# Altium schematic sheet Sheet1.SchDoc
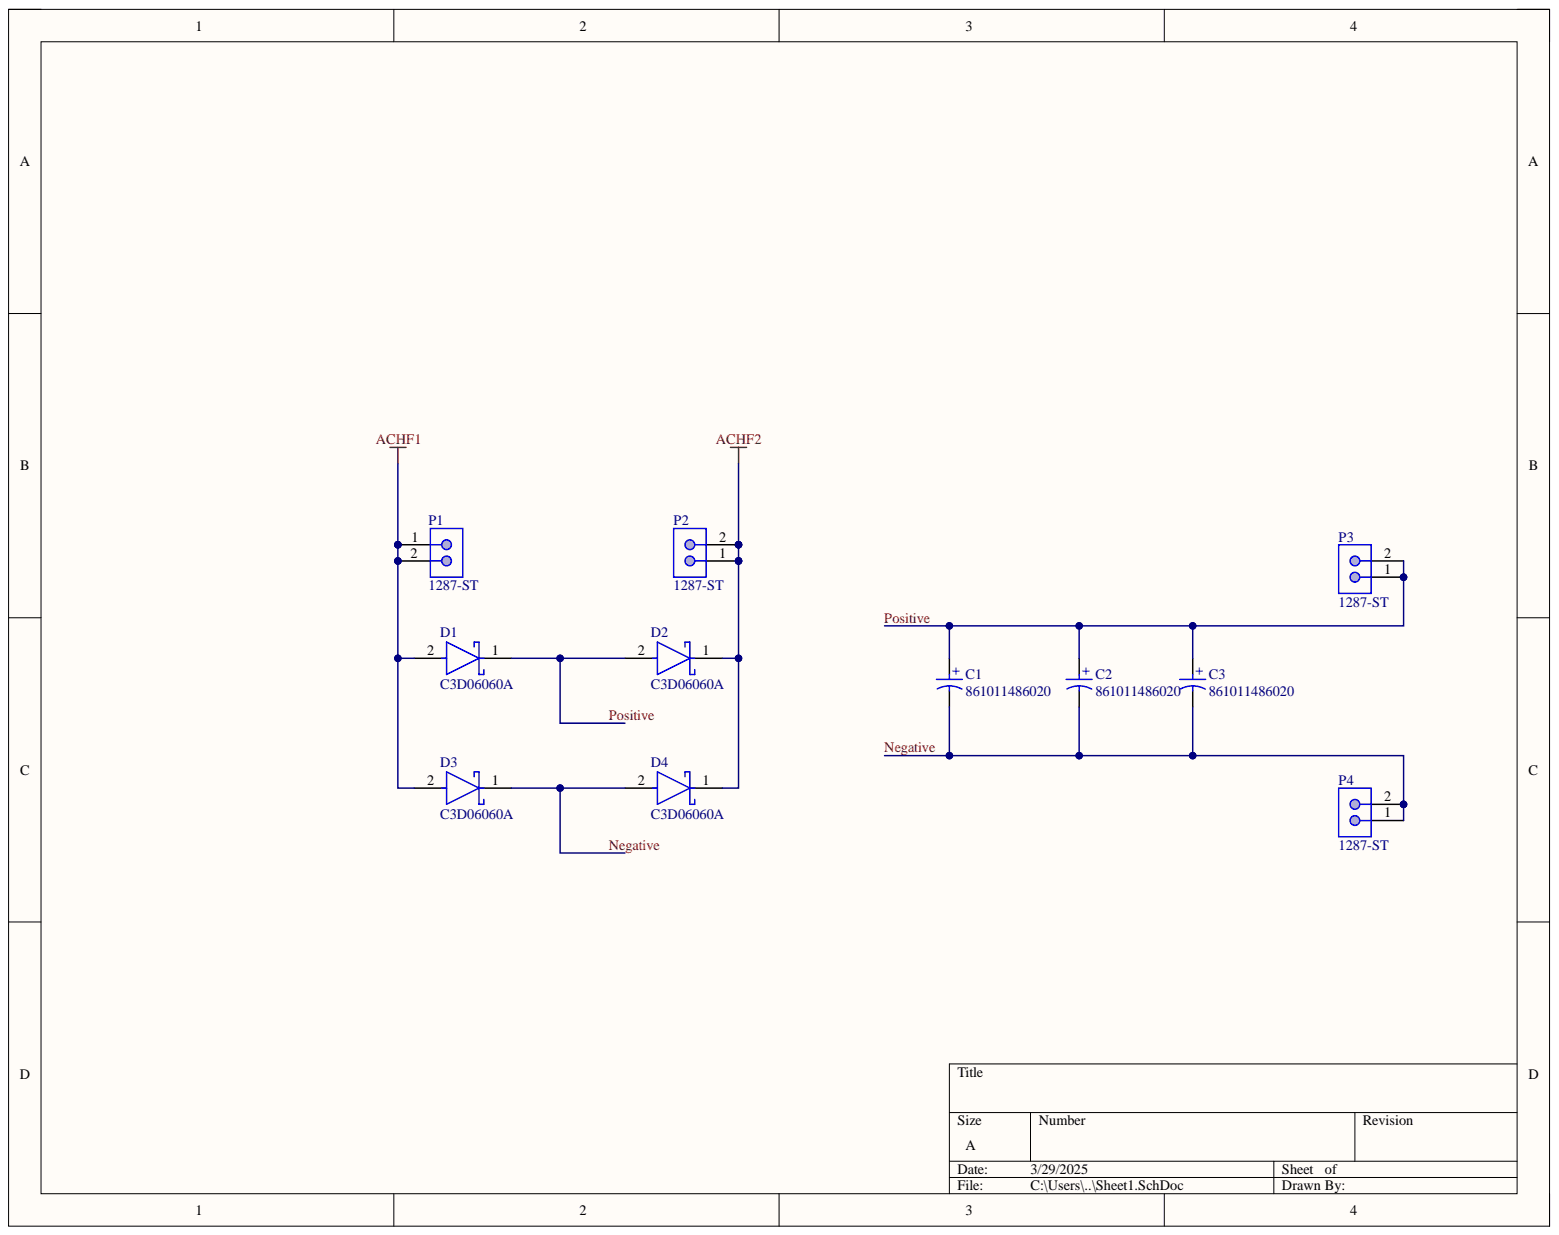
[diagram p0: Sheet1.SchDoc]

## Parts
C1  861011486020  [WCAP-AIG8_D35H37]
C2  861011486020  [WCAP-AIG8_D35H37]
C3  861011486020  [WCAP-AIG8_D35H37]
D1  C3D06060A  [FP-TO-220-2-MFG]
D2  C3D06060A  [FP-TO-220-2-MFG]
D3  C3D06060A  [FP-TO-220-2-MFG]
D4  C3D06060A  [FP-TO-220-2-MFG]
P1  1287-ST  [KSTN-1287-ST]
P2  1287-ST  [KSTN-1287-ST]
P3  1287-ST  [KSTN-1287-ST]
P4  1287-ST  [KSTN-1287-ST]

## Nets
ACHF1: D1.2 D3.2 P1.1 P1.2
ACHF2: D2.1 D4.1 P2.1 P2.2
Negative: C1.2 C2.2 C3.2 D3.1 D4.2 P4.1 P4.2
Positive: C1.1 C2.1 C3.1 D1.1 D2.2 P3.1 P3.2

## Library data of the parts
C1-C3 = CMP-03913-54202293-1: 861011486020 Electrolytic Capacitor, Snap-in, WCAP-AIG8 Series, 220 F, 20%, 450 V, 35 mm; Capacitance: 220µF; ESR (Equivalent Series Resistance): 475.56mΩ; Leakage Current: 1.98mA; Life (Hours): 2000hours; Pitch: 10mm; Polarity: Polar; Ripple Current: 1.76A; Voltage Rating: 450V; Case/Package: Radial; Component Kind: Standard; Diameter: 35mm; Height: 37mm; Height - Seated (Max): 38.989mm; Lead Pitch: 10.0076mm; Length: 37mm; Library Name: Altium Content Vault; Max Operating Temperature: 85°C; Min Operating Temperature: -25°C; Mount: Through Hole; NexarPartId: 54202293; REACH SVHC: Unknown; RoHS: Compliant; Tolerance: 20%; Voltage Rating (DC): 450V
D1-D4 = CMP-240925-000090-2: DIODE SCHOTTKY 600V 6A TO220-2; Average Rectified Current: 19A; Element Configuration: Single; Forward Voltage: 1.8V; Max Forward Surge Current (Ifsm): 70A; Max Power Dissipation: 79W; Max Reverse Current: 50uA; Reverse Recovery Time: 0ns; Case/Package: TO-220; Component Kind: Standard; Forward Current: 6A; Height: 15.621mm; Length: 10.41mm; Library Name: Altium Content Vault; Max Operating Temperature: 175°C; Max Repetitive Reverse Voltage (Vrrm): 600V; Max Reverse Voltage (DC): 600V; Min Operating Temperature: -55°C; Mount: Through Hole; Number of Pins: 2; Package Quantity: 50; Packaging: Rail/Tube; Peak Non-Repetitive Surge Current: 70A; Radiation Hardening: No; RoHS Compliant: Yes; Series: Z-Rec®; Width: 4.699mm
P1-P4 = CMP-2000-05485-1: PC Sturdi-Mount Quick-Fit Terminal, Vertical, Male, 2-Pin THD, Bulk; Manufacturer: Keystone; Angle: Straight; Finish: Tin; Finish Plating: TinPlate; Insulation Type: Non-Insulated; Material, Contact: Brass; Mounting Hole Size: 0.052; Primary Type: QuickDisconnect; Standards: ULListed; Tab Fit: 0.25; Component Kind: Standard; Contact Plating: Tin; Datasheet URL: http://www.keyelco.com/product-pdf.cfm?p=1859; Datasheet Version: Rev. C, 08/2012; Diameter, Hole: 0.052in.; Gender: Male; Length, Overall: 0.552"; Library Name: Altium Content Vault; Manufacturer URL: http://www.keyelco.com/; Material: .032[.81]Brass; Mount Angle: Straight; Mounting: VERTICAL; Mounting Technology: ThroughHole; Orientation: VERTICAL-Sturdi-Mount; Package Description: 2-Leads Quick-Fit Terminal, Pitch 5.08 mm; Package Reference: 1287-ST; Package URL: http://www.keyelco.com/product-pdf.cfm?p=1859; Terminal Style: PCBMale; Terminal Type: Quick-Fit; Termination: Solder; Type: Tab; Width, Overall: 0.312"
